# Altium schematic sheet RCU Relays.SchDoc("RCU Relays")
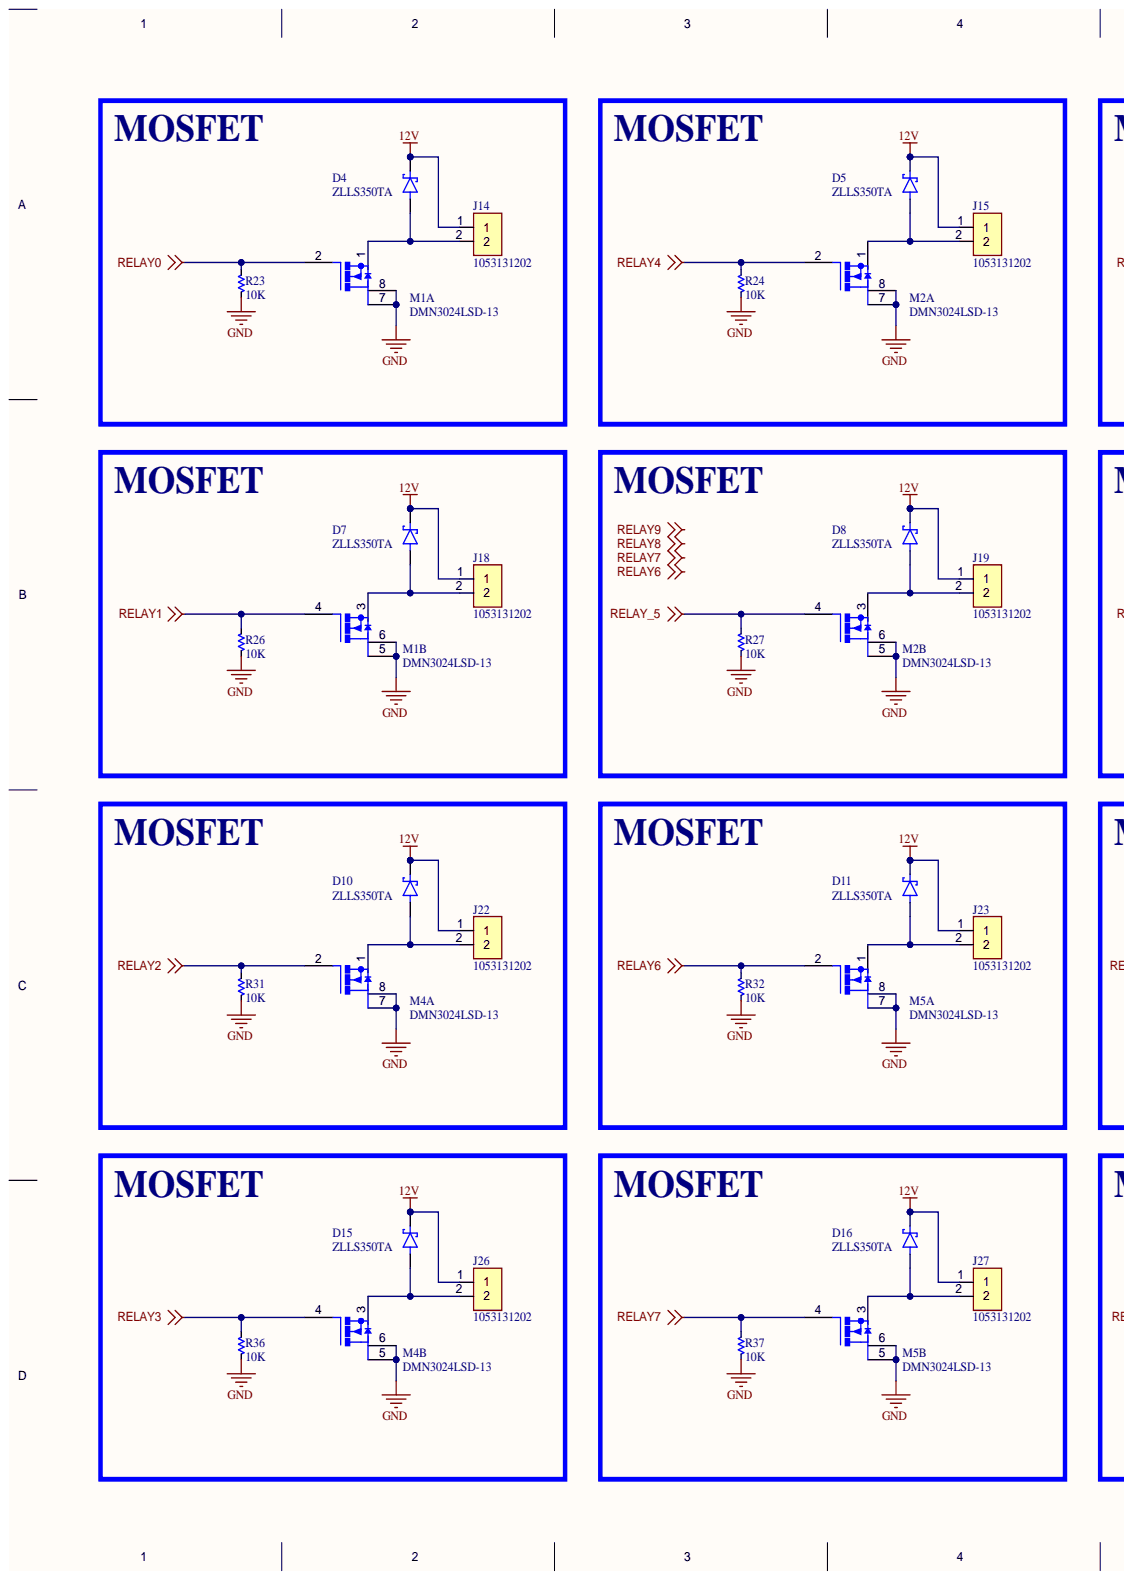
[diagram p0: RCU Relays.SchDoc, left]
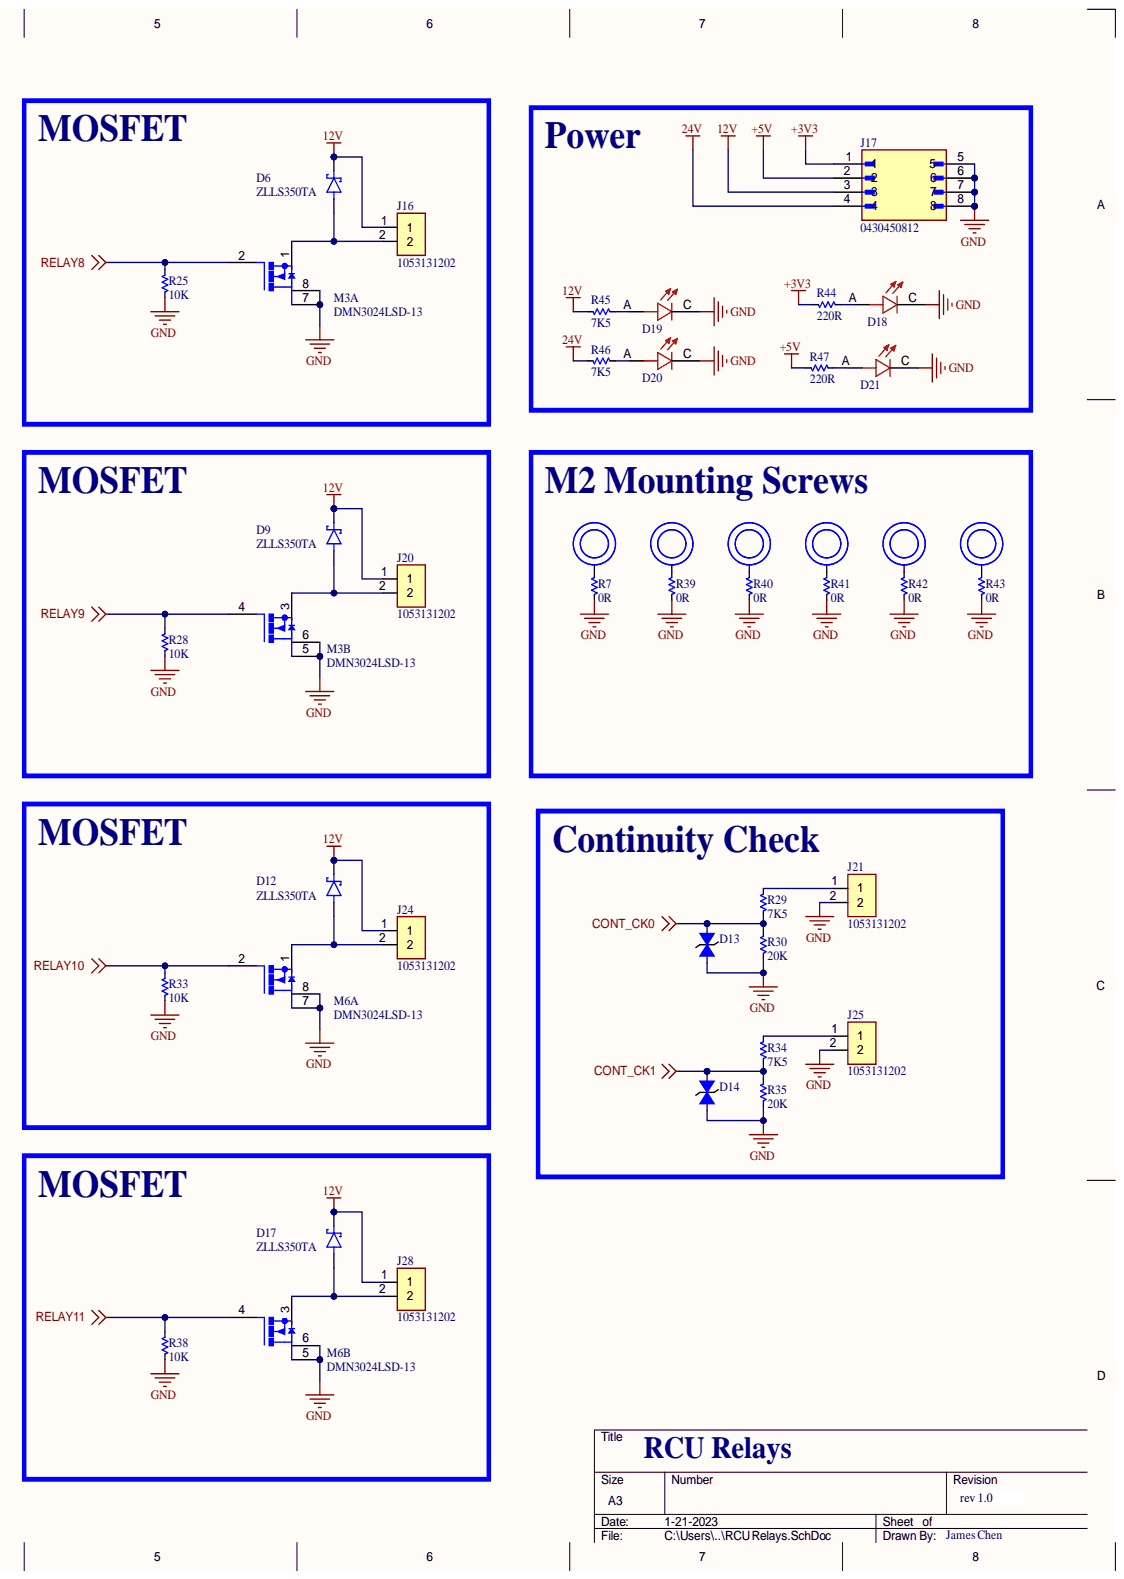
[diagram p1: RCU Relays.SchDoc, right]

## Parts
*  M2 Mounting  [M2 Screws]
*1  M2 Mounting  [M2 Screws]
*2  M2 Mounting  [M2 Screws]
*3  M2 Mounting  [M2 Screws]
*4  M2 Mounting  [M2 Screws]
*5  M2 Mounting  [M2 Screws]
D4  ZLLS350TA  [D-ZLLS350TA]
D5  ZLLS350TA  [D-ZLLS350TA]
D6  ZLLS350TA  [D-ZLLS350TA]
D7  ZLLS350TA  [D-ZLLS350TA]
D8  ZLLS350TA  [D-ZLLS350TA]
D9  ZLLS350TA  [D-ZLLS350TA]
D10  ZLLS350TA  [D-ZLLS350TA]
D11  ZLLS350TA  [D-ZLLS350TA]
D12  ZLLS350TA  [D-ZLLS350TA]
D13  CDSOD323-T12C  [D-CDSOD323-T12C]  (value not drawn)
D14  CDSOD323-T12C  [D-CDSOD323-T12C]  (value not drawn)
D15  ZLLS350TA  [D-ZLLS350TA]
D16  ZLLS350TA  [D-ZLLS350TA]
D17  ZLLS350TA  [D-ZLLS350TA]
D18  D-SML-D12U1WT86  [D-SML-D12U1WT86]  (value not drawn)
D19  D-SML-D12U1WT86  [D-SML-D12U1WT86]  (value not drawn)
D20  D-SML-D12U1WT86  [D-SML-D12U1WT86]  (value not drawn)
D21  D-SML-D12U1WT86  [D-SML-D12U1WT86]  (value not drawn)
J14  1053131202  [J-1053131202]
J15  1053131202  [J-1053131202]
J16  1053131202  [J-1053131202]
J17  0430450812  [J-0430450812]
J18  1053131202  [J-1053131202]
J19  1053131202  [J-1053131202]
J20  1053131202  [J-1053131202]
J21  1053131202  [J-1053131202]
J22  1053131202  [J-1053131202]
J23  1053131202  [J-1053131202]
J24  1053131202  [J-1053131202]
J25  1053131202  [J-1053131202]
J26  1053131202  [J-1053131202]
J27  1053131202  [J-1053131202]
J28  1053131202  [J-1053131202]
M1  DMN3024LSD-13  [M-IRF7328TRPBF]  (parts A,B)
M2  DMN3024LSD-13  [M-IRF7328TRPBF]  (parts A,B)
M3  DMN3024LSD-13  [M-IRF7328TRPBF]  (parts A,B)
M4  DMN3024LSD-13  [M-IRF7328TRPBF]  (parts A,B)
M5  DMN3024LSD-13  [M-IRF7328TRPBF]  (parts A,B)
M6  DMN3024LSD-13  [M-IRF7328TRPBF]  (parts A,B)
R7  0R  [0603]
R23  10K  [0603]
R24  10K  [0603]
R25  10K  [0603]
R26  10K  [0603]
R27  10K  [0603]
R28  10K  [0603]
R29  20K  value 7K5  [0603]
R30  20K  [0603]
R31  10K  [0603]
R32  10K  [0603]
R33  10K  [0603]
R34  20K  value 7K5  [0603]
R35  20K  [0603]
R36  10K  [0603]
R37  10K  [0603]
R38  10K  [0603]
R39  0R  [0603]
R40  0R  [0603]
R41  0R  [0603]
R42  0R  [0603]
R43  0R  [0603]
R44  220R  [0603]
R45  value 7K5  [0603]  (hidden comment: 20K)
R46  value 7K5  [0603]  (hidden comment: 20K)
R47  220R  [0603]

## Nets
12V: D4.C D5.C D6.C D7.C D8.C D9.C D10.C D11.C D12.C D15.C D16.C D17.C J14.1 J15.1 J16.1 J17.3 J18.1 J19.1 J20.1 J22.1 J23.1 J24.1 J26.1 J27.1 J28.1 R45.1
24V: J17.4 R46.1
+3V3: J17.1 R44.1
+5V: J17.2 R47.1
CONT_CK0: D13.1 R29.1 R30.2
CONT_CK1: D14.1 R34.1 R35.2
GND: D13.2 D14.2 D18.C D19.C D20.C D21.C J17.5 J17.6 J17.7 J17.8 J21.2 J25.2 M1.5 M1.6 M1.7 M1.8 M2.5 M2.6 M2.7 M2.8 M3.5 M3.6 M3.7 M3.8 M4.5 M4.6 M4.7 M4.8 M5.5 M5.6 M5.7 M5.8 M6.5 M6.6 M6.7 M6.8 R7.1 R23.1 R24.1 R25.1 R26.1 R27.1 R28.1 R30.1 R31.1 R32.1 R33.1 R35.1 R36.1 R37.1 R38.1 R39.1 R40.1 R41.1 R42.1 R43.1
RELAY0: M1.2 R23.2
RELAY1: M1.4 R26.2
RELAY2: M4.2 R31.2
RELAY3: M4.4 R36.2
RELAY4: M2.2 R24.2
RELAY6: M5.2 R32.2
RELAY7: M5.4 R37.2
RELAY8: M3.2 R25.2
RELAY9: M3.4 R28.2
RELAY10: M6.2 R33.2
RELAY11: M6.4 R38.2
RELAY_5: M2.4 R27.2
Net*1_1: *1.1 R39.2
Net*2_1: *2.1 R40.2
Net*3_1: *3.1 R41.2
Net*4_1: *4.1 R42.2
Net*5_1: *5.1 R43.2
Net*_1: *.1 R7.2
NetD4_A: D4.A J14.2 M1.1
NetD5_A: D5.A J15.2 M2.1
NetD6_A: D6.A J16.2 M3.1
NetD7_A: D7.A J18.2 M1.3
NetD8_A: D8.A J19.2 M2.3
NetD9_A: D9.A J20.2 M3.3
NetD10_A: D10.A J22.2 M4.1
NetD11_A: D11.A J23.2 M5.1
NetD12_A: D12.A J24.2 M6.1
NetD15_A: D15.A J26.2 M4.3
NetD16_A: D16.A J27.2 M5.3
NetD17_A: D17.A J28.2 M6.3
NetD18_A: D18.A R44.2
NetD19_A: D19.A R45.2
NetD20_A: D20.A R46.2
NetD21_A: D21.A R47.2
NetJ21_1: J21.1 R29.2
NetJ25_1: J25.1 R34.2

## Library data of the parts
D4-D12 D15-D17 = D-ZLLS350TA: DIODE SCHOTTKY 40V 380MA SOD523; Manufacturer: Diodes Incorporated; Component Kind: Standard; Distributor: Digikey; Distributor Part #: ZLLS350TACT-ND; Manufacturer Part #: ZLLS350TA; Library Name: RCU Board rev1.0.SchLib
D13 D14 = D-CDSOD323-T12C: TVS DIODE 12VWM 28.3VC SOD323; Manufacturer: Bourns Inc.; Component Kind: Standard; Distributor: Digikey; Distributor Part #: CDSOD323-T12CCT-ND; Manufacturer Part #: CDSOD323-T12C; Library Name: RCU Board rev1.0.SchLib
D18-D21 = D-SML-D12U1WT86: Red 620nm LED Indication - Discrete 2.2V 0603 _1608 Metric; Manufacturer: Rohm Semiconductor; Component Kind: Standard; Distributor: Digikey; Distributor Part #: SML-D12U1WT86CT-ND; Manufacturer Part #: SML-D12U1WT86; Library Name: RCU Board rev1.0.SchLib
J14-J16 J18-J28 = J-1053131202: CONN HEADER R/A 2POS 2.5MM 8A; Manufacturer: Molex; Component Kind: Standard; Distributor: Digikey; Distributor Part #: WM14972-ND; Manufacturer Part #: 1053131202; Library Name: RCU Board rev1.0.SchLib
J17 = J-0430450812: CONN HEADER VERT 8POS 3MM; Manufacturer: Molex; Component Kind: Standard; Distributor: Digikey; Distributor Part #: WM1792-ND; Manufacturer Part #: 0430450812; Library Name: RCU Board rev1.0.SchLib
M1-M6 = M-DMN3024LSD-13: MOSFET 2N-CH 30V 6.8A 8SO; Manufacturer: Diodes Incorporated; Component Kind: Standard; Distributor: Digikey; Distributor Part #: DMN3024LSD-13DICT-ND; Manufacturer Part #: DMN3024LSD-13; Library Name: RCU Board rev1.0.SchLib
R7 R39-R43 = RT-0R00-F-1/10W-0603: 0 Ohms Jumper 0.1W, 1/10W Chip Resistor 0603 (1608 Metric) Automotive AEC-Q200 Thick Film; Manufacturer: Stackpole Electronics Inc; Manufacturer Part #: RMCF0603ZT0R00; Component Kind: Standard; ComponentLink1description: Datasheet; ComponentLink1URL: https://industrial.panasonic.com/ww/products/resistors/chip-resistors/general-purpose-chip-resistors/models/ERJ2GE0R00X; ComponentLink2description: Manufacturer Website; ComponentLink2URL: https://industrial.panasonic.com/ww/products/resistors/chip-resistors/general-purpose-chip-resistors/models/ERJ2GE0R00X; Distributor: Digikey; Distributor Part #: RMCF0603ZT0R00CT-ND; Part Description: 0 Ohms Jumper 0.1W, 1/10W Chip Resistor 0603 (1608 Metric) Automotive AEC-Q200 Thick Film; Value: 0R; Library Name: RCU Board rev1.0.SchLib
R23-R28 R31-R33 R36-R38 = RT-10K0-F-1/16W-0603: RES 10K OHM 1% 1/8W 0603; Manufacturer: Stackpole Electronics Inc; Manufacturer Part #: RNCP0603FTD10K0; Component Kind: Standard; ComponentLink1description: Datasheet; ComponentLink1URL: https://www.vishay.com/docs/20035/dcrcwe3.pdf; ComponentLink2description: Manufacturer Website; ComponentLink2URL: https://www.vishay.com/; Distributor: Digikey; Distributor Part #: RNCP0603FTD10K0CT-ND; Part Description: RES 10K OHM 1% 1/8W 0603; Value: 10K; Library Name: RCU Board rev1.0.SchLib
R29 R34 R45 R46 = RT-7K5-F-1/16W-0603: RES 7.5K OHM 1% 1/8W 0603; Manufacturer: Stackpole Electronics Inc; Manufacturer Part #: RNCP0603FTD7K50; Component Kind: Standard; ComponentLink1description: Datasheet; ComponentLink1URL: https://www.vishay.com/docs/20035/dcrcwe3.pdf; ComponentLink2description: Manufacturer Website; ComponentLink2URL: https://www.vishay.com/; Distributor: Digikey; Distributor Part #: RNCP0603FTD7K50CT-ND; Part Description: RES 7.5K OHM 1% 1/8W 0603; Library Name: RCU Board rev1.0.SchLib
R30 R35 = RT-20K0-F-1/16W-0603: RES 20K OHM 1% 1/10W 0603; Manufacturer: Stackpole Electronics Inc; Manufacturer Part #: RNCP0603FTD20K0; Component Kind: Standard; ComponentLink1description: Datasheet; ComponentLink1URL: https://www.vishay.com/docs/20035/dcrcwe3.pdf; ComponentLink2description: Manufacturer Website; ComponentLink2URL: https://www.vishay.com/; Distributor: Digikey; Distributor Part #: RNCP0603FTD20K0CT-ND; Part Description: RES 20K OHM 1% 1/10W 0603; Value: 20K; Library Name: RCU Board rev1.0.SchLib
R44 R47 = RT-220R-F-1/16W-0603: 220 Ohms ±0.5% 0.063W, 1/16W Chip Resistor 0603 (1608 Metric) - Thin Film; Manufacturer: Susumu; Manufacturer Part #: RR0816P-221-D; Component Kind: Standard; Distributor: Digikey; Distributor Part #: RR08P220DCT-ND; Part Description: 220 Ohms ±0.5% 0.063W, 1/16W Chip Resistor 0603 (1608 Metric) - Thin Film; Value: 220R; Library Name: RCU Board rev1.0.SchLib
* *1-*5 = M2 Mounting: Component Kind: Standard; Library Name: RCU Board rev1.0.SchLib
